# Altium schematic sheet AFE_mezzanine.SchDoc
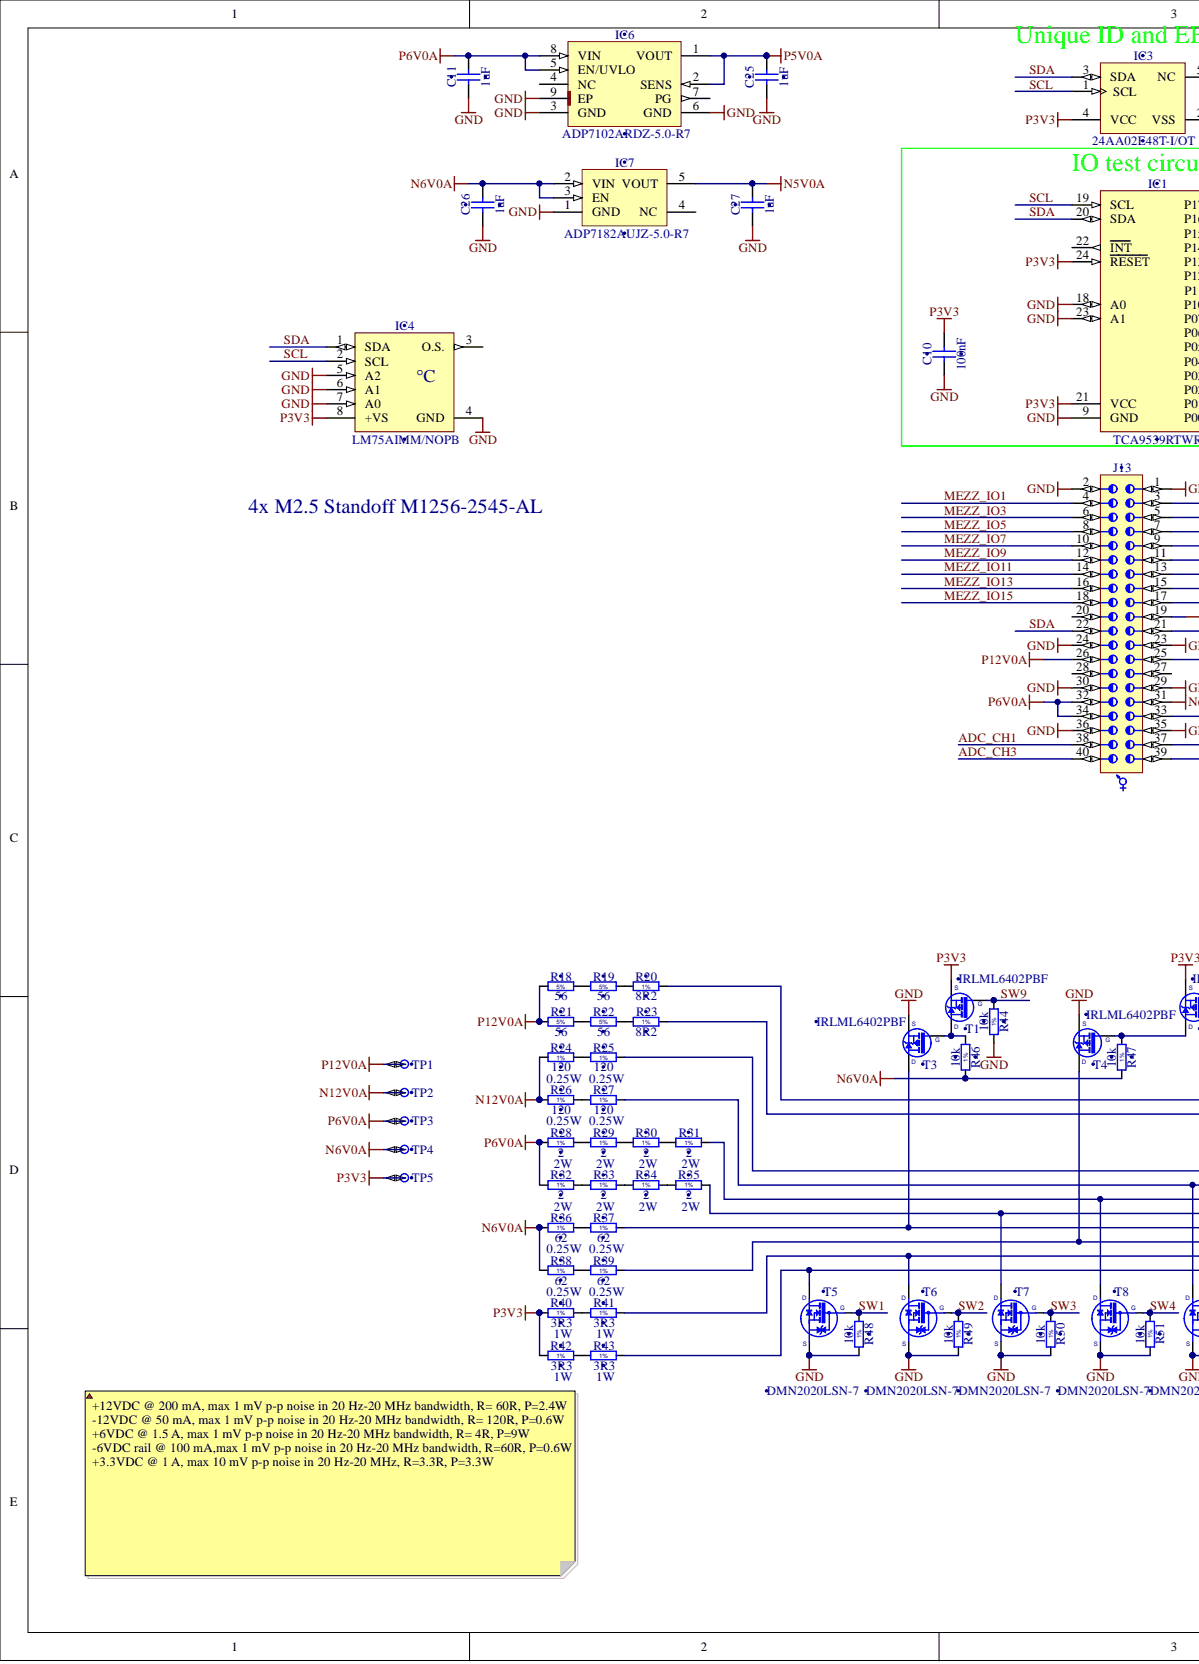
[diagram p0: AFE_mezzanine.SchDoc, left]
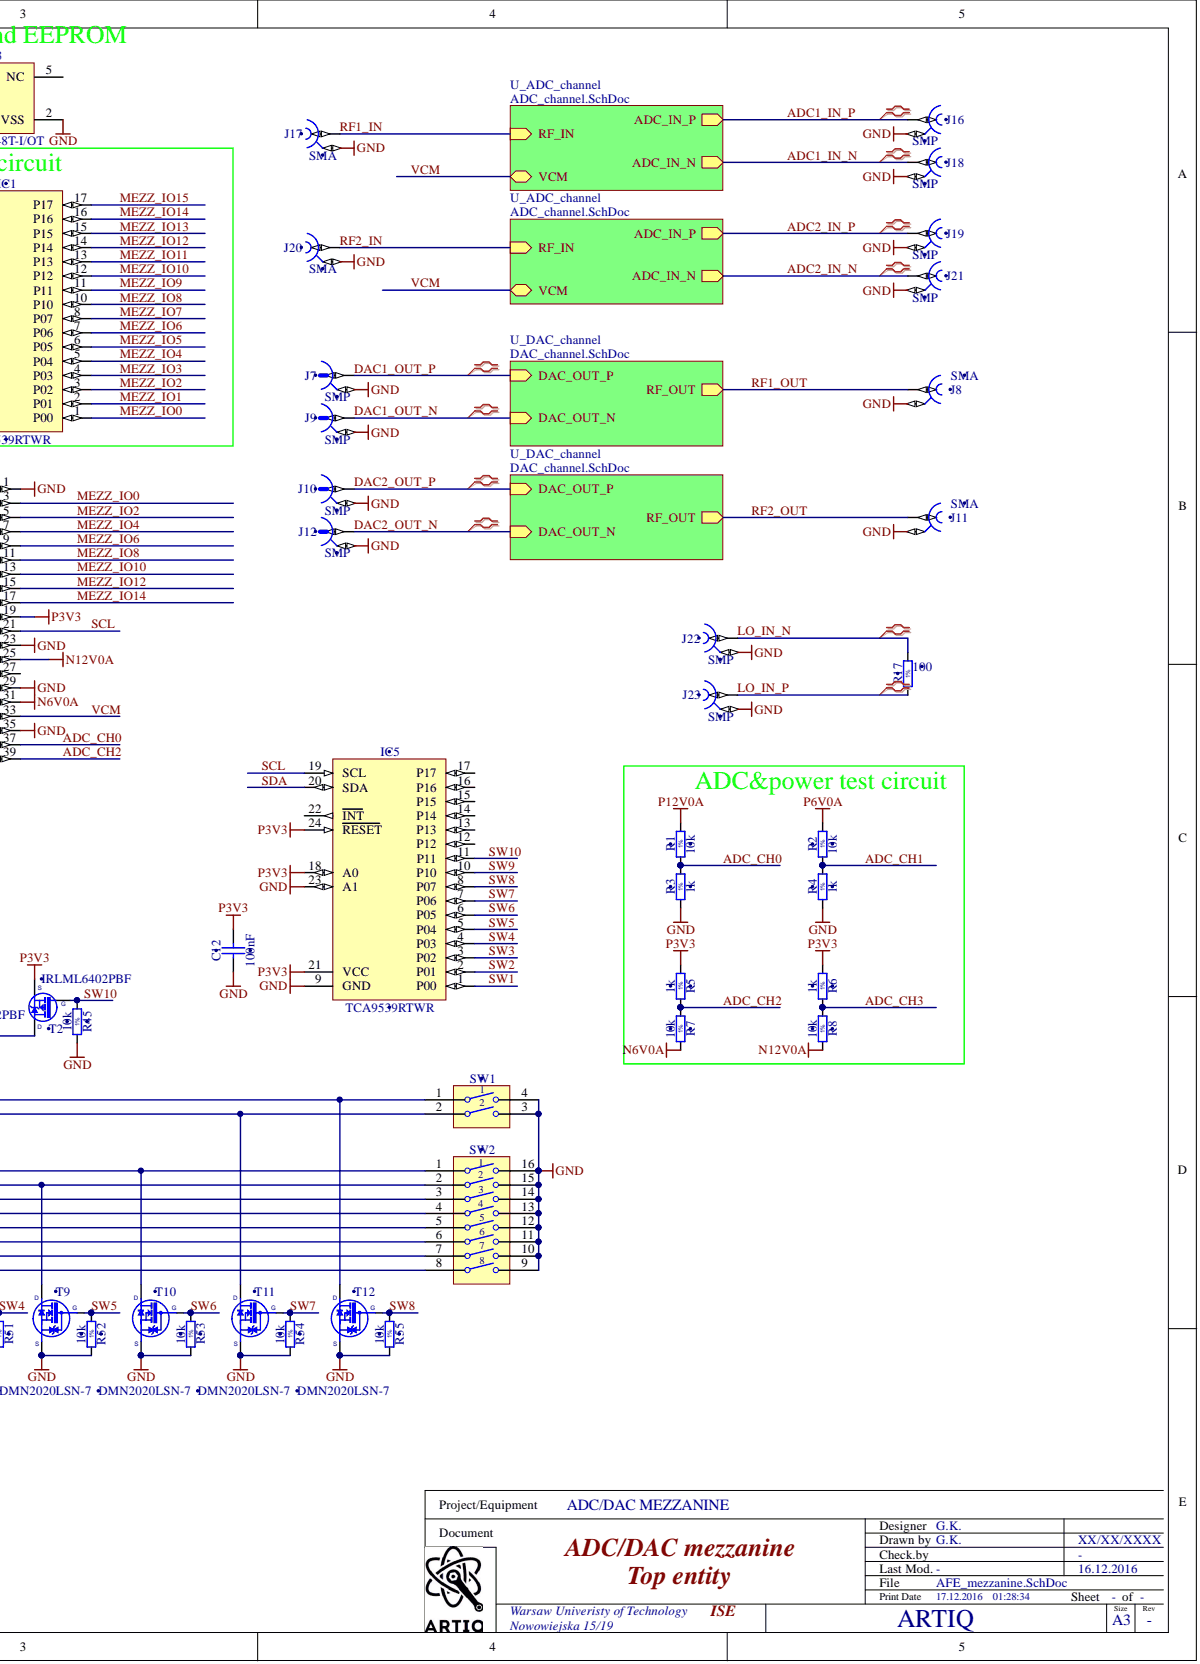
[diagram p1: AFE_mezzanine.SchDoc, right]

## Parts
C10  100nF  [CAPC1005X55N]
C11  1uF  [CAPC2012X140N]
C12  100nF  [CAPC1005X55N]
C25  1uF  [CAPC2012X140N]
C26  1uF  [CAPC2012X140N]
C27  1uF  [CAPC2012X140N]
IC1  TCA9539RTWR  [QFN50P400X400X80-25N-S255]
IC3  24AA02E48T-I/OT  [SOT95P280X145-5N]
IC4  LM75AIMM/NOPB  [SOP65P490X109-8N]
IC5  TCA9539RTWR  [QFN50P400X400X80-25N-S255]
IC6  ADP7102ARDZ-5.0-R7  [SOIC127P600X175-9N-R241X309]
IC7  ADP7182AUJZ-5.0-R7  [SOT95P280X90-5N]
J7  SMP  [MOLEX_85305-0232]
J8  SMA  [TELEGARTNER_J01151A0451]
J9  SMP  [MOLEX_85305-0232]
J10  SMP  [MOLEX_85305-0232]
J11  SMA  [TELEGARTNER_J01151A0451]
J12  SMP  [MOLEX_85305-0232]
J13  Familly  [SAMTEC_LSS-120-03-L-DV-A]  (value not drawn)
J16  SMP  [AMPHENOL_SMP-MSLD-PCT-10]
J17  SMA  [TELEGARTNER_J01151A0921]
J18  SMP  [AMPHENOL_SMP-MSLD-PCT-10]
J19  SMP  [AMPHENOL_SMP-MSLD-PCT-10]
J20  SMA  [TELEGARTNER_J01151A0921]
J21  SMP  [AMPHENOL_SMP-MSLD-PCT-10]
J22  SMP  [AMPHENOL_SMP-MSLD-PCT-10]
J23  SMP  [AMPHENOL_SMP-MSLD-PCT-10]
R1  10k  [RESC1005X40N]
R2  10k  [RESC1005X40N]
R3  1k  [RESC1005X40N]
R4  1k  [RESC1005X40N]
R5  1k  [RESC1005X40N]
R6  1k  [RESC1005X40N]
R7  10k  [RESC1005X40N]
R8  10k  [RESC1005X40N]
R17  100  [RESC1005X40N]
R18  56  [RESC6331X70N]
R19  56  [RESC6331X70N]
R20  8R2  [RESC3216X65N]
R21  56  [RESC6331X70N]
R22  56  [RESC6331X70N]
R23  8R2  [RESC3216X65N]
R24  120  [RESC3216X65N]
R25  120  [RESC3216X65N]
R26  120  Power: 0.25W  [RESC3216X65N]
R27  120  Power: 0.25W  [RESC3216X65N]
R28  2  [RESM11670X449N]
R29  2  [RESM11670X449N]
R30  2  [RESM11670X449N]
R31  2  [RESM11670X449N]
R32  2  Power: 2W  [RESM11670X449N]
R33  2  Power: 2W  [RESM11670X449N]
R34  2  Power: 2W  [RESM11670X449N]
R35  2  Power: 2W  [RESM11670X449N]
R36  62  [RESC3216X65N]
R37  62  [RESC3216X65N]
R38  62  Power: 0.25W  [RESC3216X65N]
R39  62  Power: 0.25W  [RESC3216X65N]
R40  3R3  [RESC6331X70N]
R41  3R3  [RESC6331X70N]
R42  3R3  Power: 1W  [RESC6331X70N]
R43  3R3  Power: 1W  [RESC6331X70N]
R44  10k  [RESC1005X40N]
R45  10k  [RESC1005X40N]
R46  10k  [RESC1005X40N]
R47  10k  [RESC1005X40N]
R48  10k  [RESC1005X40N]
R49  10k  [RESC1005X40N]
R50  10k  [RESC1005X40N]
R51  10k  [RESC1005X40N]
R52  10k  [RESC1005X40N]
R53  10k  [RESC1005X40N]
R54  10k  [RESC1005X40N]
R55  10k  [RESC1005X40N]
SW1  Switch  [SW_OMRON_A6S-2102-H]  (value not drawn)
SW2  Switch  [SW_OMRON_A6S-8102-H]  (value not drawn)
T1  IRLML6402PBF  [SOT95P237X112-3N]
T2  IRLML6402PBF  [SOT95P237X112-3N]
T3  IRLML6402PBF  [SOT95P237X112-3N]
T4  IRLML6402PBF  [SOT95P237X112-3N]
T5  DMN2020LSN-7  [SOT95P285X140-3N]
T6  DMN2020LSN-7  [SOT95P285X140-3N]
T7  DMN2020LSN-7  [SOT95P285X140-3N]
T8  DMN2020LSN-7  [SOT95P285X140-3N]
T9  DMN2020LSN-7  [SOT95P285X140-3N]
T10  DMN2020LSN-7  [SOT95P285X140-3N]
T11  DMN2020LSN-7  [SOT95P285X140-3N]
T12  DMN2020LSN-7  [SOT95P285X140-3N]
TP1  Test Point  [TPS127]  (value not drawn)  (no BOM)
TP2  Test Point  [TPS127]  (value not drawn)  (no BOM)
TP3  Test Point  [TPS127]  (value not drawn)  (no BOM)
TP4  Test Point  [TPS127]  (value not drawn)  (no BOM)
TP5  Test Point  [TPS127]  (value not drawn)  (no BOM)

## Nets
GND: C10.1 C11.1 C12.1 C25.1 C26.1 C27.1 IC1.9(GND) IC1.18(A0) IC1.23(A1) IC3.2(VSS) IC4.4(GND) IC4.5(A2) IC4.6(A1) IC4.7(A0) IC5.9(GND) IC5.23(A1) IC6.3(GND) IC6.6(GND) IC6.9(EP) IC7.1(GND) J7.2 J8.2 J9.2 J10.2 J11.2 J12.2 J13.1 J13.2 J13.23 J13.24 J13.29 J13.30 J13.35 J13.36 J16.2 J17.2 J18.2 J19.2 J20.2 J21.2 J22.2 J23.2 R3.1 R4.1 R44.2 R45.2 R48.2 R49.2 R50.2 R51.2 R52.2 R53.2 R54.2 R55.2 SW1.3 SW1.4 SW2.9 SW2.10 SW2.11 SW2.12 SW2.13 SW2.14 SW2.15 SW2.16 T3.2 T4.2 T5.2 T6.2 T7.2 T8.2 T9.2 T10.2 T11.2 T12.2
N5V0A: C27.2 IC7.5(VOUT)
N6V0A: C26.2 IC7.2(VIN) IC7.3(EN) J13.31 R7.2 R36.1 R38.1 R46.2 R47.2 TP4.1
N12V0A: J13.25 R8.2 R24.1 R26.1 TP2.1
P3V3: C10.2 C12.2 IC1.21(VCC) IC1.24(RESET) IC3.4(VCC) IC4.8(+VS) IC5.18(A0) IC5.21(VCC) IC5.24(RESET) J13.19 R5.1 R6.1 R40.1 R42.1 T1.2 T2.2 TP5.1
P5V0A: C25.2 IC6.1(VOUT) IC6.2(SENS)
P6V0A: C11.2 IC6.5(EN/UVLO) IC6.8(VIN) J13.32 J13.34 R2.2 R28.1 R32.1 TP3.1
P12V0A: J13.26 R1.2 R18.1 R21.1 TP1.1
ADC1_IN_N: J18.1
ADC1_IN_P: J16.1
ADC2_IN_N: J21.1
ADC2_IN_P: J19.1
ADC_CH0: J13.37 R1.1 R3.2
ADC_CH1: J13.38 R2.1 R4.2
ADC_CH2: J13.39 R5.2 R7.1
ADC_CH3: J13.40 R6.2 R8.1
DAC1_OUT_N: J9.1
DAC1_OUT_P: J7.1
DAC2_OUT_N: J12.1
DAC2_OUT_P: J10.1
LO_IN_N: J22.1 R17.2
LO_IN_P: J23.1 R17.1
MEZZ_IO0: IC1.1(P00) J13.3
MEZZ_IO1: IC1.2(P01) J13.4
MEZZ_IO2: IC1.3(P02) J13.5
MEZZ_IO3: IC1.4(P03) J13.6
MEZZ_IO4: IC1.5(P04) J13.7
MEZZ_IO5: IC1.6(P05) J13.8
MEZZ_IO6: IC1.7(P06) J13.9
MEZZ_IO7: IC1.8(P07) J13.10
MEZZ_IO8: IC1.10(P10) J13.11
MEZZ_IO9: IC1.11(P11) J13.12
MEZZ_IO10: IC1.12(P12) J13.13
MEZZ_IO11: IC1.13(P13) J13.14
MEZZ_IO12: IC1.14(P14) J13.15
MEZZ_IO13: IC1.15(P15) J13.16
MEZZ_IO14: IC1.16(P16) J13.17
MEZZ_IO15: IC1.17(P17) J13.18
RF1_IN: J17.1
RF1_OUT: J8.1
RF2_IN: J20.1
RF2_OUT: J11.1
SCL: IC1.19(SCL) IC3.1(SCL) IC4.2(SCL) IC5.19(SCL) J13.21
SDA: IC1.20(SDA) IC3.3(SDA) IC4.1(SDA) IC5.20(SDA) J13.22
SW1: IC5.1(P00) R48.1 T5.1
SW2: IC5.2(P01) R49.1 T6.1
SW3: IC5.3(P02) R50.1 T7.1
SW4: IC5.4(P03) R51.1 T8.1
SW5: IC5.5(P04) R52.1 T9.1
SW6: IC5.6(P05) R53.1 T10.1
SW7: IC5.7(P06) R54.1 T11.1
SW8: IC5.8(P07) R55.1 T12.1
SW9: IC5.10(P10) R44.1 T1.1
SW10: IC5.11(P11) R45.1 T2.1
VCM: J13.33
NetIC1_22: IC1.22(INT)
NetIC3_5: IC3.5(NC)
NetIC4_3: IC4.3(O.S.)
NetIC5_12: IC5.12(P12)
NetIC5_13: IC5.13(P13)
NetIC5_14: IC5.14(P14)
NetIC5_15: IC5.15(P15)
NetIC5_16: IC5.16(P16)
NetIC5_17: IC5.17(P17)
NetIC5_22: IC5.22(INT)
NetIC6_4: IC6.4(NC)
NetIC6_7: IC6.7(PG)
NetIC7_4: IC7.4(NC)
NetJ13_20: J13.20
NetJ13_27: J13.27
NetJ13_28: J13.28
NetR18_2: R18.2 R19.1
NetR19_2: R19.2 R20.1
NetR20_2: R20.2 SW1.1 T12.3
NetR21_2: R21.2 R22.1
NetR22_2: R22.2 R23.1
NetR23_2: R23.2 SW1.2 T11.3
NetR24_2: R24.2 R25.1
NetR25_2: R25.2 SW2.1 T10.3
NetR26_2: R26.2 R27.1
NetR27_2: R27.2 SW2.2 T9.3
NetR28_2: R28.2 R29.1
NetR29_2: R29.2 R30.1
NetR30_2: R30.2 R31.1
NetR31_2: R31.2 SW2.3 T8.3
NetR32_2: R32.2 R33.1
NetR33_2: R33.2 R34.1
NetR34_2: R34.2 R35.1
NetR35_2: R35.2 SW2.4 T7.3
NetR36_2: R36.2 R37.1
NetR37_2: R37.2 SW2.5 T3.3
NetR38_2: R38.2 R39.1
NetR39_2: R39.2 SW2.6 T4.3
NetR40_2: R40.2 R41.1
NetR41_2: R41.2 SW2.7 T6.3
NetR42_2: R42.2 R43.1
NetR43_2: R43.2 SW2.8 T5.3
NetR46_1: R46.1 T1.3 T3.1
NetR47_1: R47.1 T2.3 T4.1

## Library data of the parts
C10 C12 = CC0402_100NF_16V_10%_X5R: Non-polarised capacitor; Manufacturer: GENERIC; Manufacturer1 Part Number: GRM155R61C104KA88D; Part Description: SMD Multilayer Chip Ceramic Capacitor; Database Table Name: Capacitors SMD; TC: X5R; Tolerance: ±10%; Voltage: 16V; Case: 0402; Component Kind: Standard; Component Type: Standard; CreateDate: 10.12.2013; HelpURL: \\cern.ch\dfs\Applications\Altium\Datasheets\CC0402_X5R_MURATA_GRM.pdf; LatestRevisionDate: 10.12.2013; Library Name: Capacitors.DbLib; Manufacturer1 ComponentHeight: 0.55mm; Manufacturer1 Example: MURATA; Manufacturer Part Number: CC0402_100NF_16V_10%_X5R; Mounted: Yes; Part Number: CC0402_100NF_16V_10%_X5R; Pin Count: 2; Sense: No; SMD: Yes; Socket: No; Status: None; Value: 100nF; Author: CERN DEM JLC
C11 C25-C27 = CC0805_1UF_35V_20%_X7R: Non-polarised capacitor; Manufacturer: GENERIC; Manufacturer1 Part Number: GMK212B7105MG-T; Part Description: SMD Multilayer Chip Ceramic Capacitor; Database Table Name: Capacitors SMD; TC: X7R; Tolerance: ±20%; Voltage: 35V; Case: 0805; Component Kind: Standard; Component Type: Standard; CreateDate: 22.06.2011; HelpURL: \\cern.ch\dfs\Applications\Altium\Datasheets\CC0805_X7R_TAIYO-YUDEN_HIGH-VALUE.pdf; LatestRevisionDate: 22.06.2011; Library Name: Capacitors.DbLib; Manufacturer1 ComponentHeight: 1.35mm; Manufacturer1 Example: TAIYO YUDEN; Manufacturer Part Number: CC0805_1UF_35V_20%_X7R; Mounted: Yes; Part Number: CC0805_1UF_35V_20%_X7R; Pin Count: 2; Sense: No; SMD: Yes; Socket: No; Status: Not Recommended; Value: 1uF; Author: CERN DEM JLC
IC1 IC5 = TCA9539RTWR: Low-Voltage 16-Bit I2C and SMBus I/O Expander; Manufacturer: TEXAS INSTRUMENTS; Part Description: Low Voltage 16-Bit I2C and SMBus Low-Power I/O Expander With Interrupt Output, Reset Pin, Configuration Registers; Bonding: No; Database Table Name: Analog & Interface; PressFit: No; Case: QFN24; ComponentHeight: 0.8mm; Component Kind: Standard; Component Type: Standard; CreateDate: 01.12.2015; Device: TCA9539RTWR; HelpURL: \\cern.ch\dfs\Applications\Altium\Datasheets\TCA9539RTWR.pdf; LatestRevisionDate: 01.12.2015; Library Name: ICs And Semiconductors.DbLib; Manufacturer Part Number: TCA9539RTWR; Mounted: Yes; PackageDescription: QFN, 0.50mm Pitch, Square; 24 Pin, 4.00mm X 4.00mm X 0.80mm H Body (w/Thermal Tab 2.55 X 2.55 mm), IPC Medium Density; Part Number: TCA9539RTWR; Pin Count: 25; Sense: No; SMD: Yes; Socket: No; Author: CERN DEM MR
IC3 = 24AA02E48T-I/OT: 1.7V-5.5V, 2K I2C Serial EEPROM With EUI-48 Node Identity; Manufacturer: MICROCHIP TECHNOLOGY; Bonding: No; Database Table Name: Logic; PressFit: No; Case: SOT23-5; ComponentHeight: 1.45mm; Component Kind: Standard; Component Type: Standard; CreateDate: 31.03.2016; Device: 24AA02E48T-I/OT; HelpURL: \\cern.ch\dfs\Applications\Altium\Datasheets\24AA02E48T-I-OT.pdf; LatestRevisionDate: 31.03.2016; Library Name: ICs And Semiconductors.DbLib; Manufacturer Part Number: 24AA02E48T-I/OT; Mounted: Yes; PackageDescription: SOT, 0.95mm pitch; 5 pin, 1.60mm W X 2.90mm L X 1.45mm H body, IPC Medium Density; Part Description: 1.7V-5.5V, 2K I2C Serial EEPROM With EUI-48 Node Identity; Part Number: 24AA02E48T-I/OT; Pin Count: 5; Sense: No; SMD: Yes; Socket: No; Author: CERN DEM MR
IC4 = LM75AIMM/NOPB: Digital Temperature Sensor and Thermal Watchdog with Two-Wire Interface; Manufacturer: TEXAS INSTRUMENTS; Part Description: -25° to +100°C ±2°C Digital Temperature Sensor and Thermal Watchdog with Two-Wire Interface; Bonding: No; Database Table Name: Sensors; PressFit: No; Case: SSOP8; ComponentHeight: 1.09mm; Component Kind: Standard; Component Type: Standard; CreateDate: 15.10.2012; Device: LM75AIMM/NOPB; HelpURL: \\cern.ch\dfs\Applications\Altium\Datasheets\LM75AIMM-NOPB.pdf; LatestRevisionDate: 15.10.2012; Library Name: ICs And Semiconductors.DbLib; Manufacturer Part Number: LM75AIMM/NOPB; Mounted: Yes; PackageDescription: SOP, 0.65mm pitch; 8 pin,3.00mm W X 3.00mm L X 1.09mm H bodyI, PC Medium Density; Part Number: LM75AIMM/NOPB; Pin Count: 8; Sense: No; SMD: Yes; Socket: No; Author: CERN DEM JLC
IC6 = ADP7102ARDZ-5.0-R7: 300 mA, Low Noise, CMOS LDO Linear Regulator; Manufacturer: ANALOG DEVICES; Part Description: 5V, 300mA, Low Noise, CMOS LDO Linear Regulator; Bonding: No; Database Table Name: Regulators; PressFit: No; Case: SOIC8; ComponentHeight: 1.75mm; Component Kind: Standard; Component Type: Standard; CreateDate: 17.03.2014; Device: ADP7102ARDZ-5.0-R7; HelpURL: \\cern.ch\dfs\Applications\Altium\Datasheets\ADP7102ARDZ-5.0-R7.pdf; LatestRevisionDate: 17.03.2014; Library Name: ICs And Semiconductors.DbLib; Manufacturer Part Number: ADP7102ARDZ-5.0-R7; Mounted: Yes; PackageDescription: SOIC 8, Pitch 1.27mm - Body 3.9x4.9mm, (w/thermal tab 2.41mm X 3.098mm), IPC Medium Density; Part Number: ADP7102ARDZ-5.0-R7; Pin Count: 8; Sense: No; SMD: Yes; Socket: No; Author: CERN DEM JLC
IC7 = ADP7182AUJZ-5.0-R7: –5V, 200 mA, Low Noise, Linear Regulator; Manufacturer: ANALOG DEVICES; Part Description: –5V, -200mA, Low Noise, Linear Regulator; Bonding: No; Database Table Name: Regulators; PressFit: No; Case: SOT23-5; ComponentHeight: 0.9mm; Component Kind: Standard; Component Type: Standard; CreateDate: 17.03.2014; Device: ADP7182AUJZ-5.0-R7; HelpURL: \\cern.ch\dfs\Applications\Altium\Datasheets\ADP7182AUJZ-5.0-R7.pdf; LatestRevisionDate: 17.03.2014; Library Name: ICs And Semiconductors.DbLib; Manufacturer Part Number: ADP7182AUJZ-5.0-R7; Mounted: Yes; PackageDescription: SOT23, 0.95mm pitch; 5 pin, 1.75mm W X 3.00mm L, IPC Medium Density; Part Number: ADP7182AUJZ-5.0-R7; Pin Count: 5; Sense: No; SMD: Yes; Socket: No; Author: CERN DEM JLC
J7 J9 J10 J12 = MOLEX_85305-0232: Part Description: 50 Ohms, SMP Surface Mount Plug, Limited Detent; Database Table Name: MOLEX; PressFit: No; ComponentHeight: 4.1mm; Component Kind: Standard; Component Type: Standard; CreateDate: 09.08.2016; Family: SMP; HelpURL: \\cern.ch\dfs\Applications\Altium\Datasheets\MOLEX_85305-0232.pdf; LatestRevisionDate: 09.08.2016; Library Name: Connectors.DbLib; Manufacturer: MOLEX; Manufacturer Part Number: 85305-0232; Mounted: Yes; Part Number: MOLEX_85305-0232; Pin Count: 2; Sense: No; SMD: Yes; Socket: No; Status: None; Author: CERN DEM JMW
J8 J11 = TELEGARTNER_J01151A0451: Part Description: SMA 50 ohms Edge Mount Bulkhead Jack Receptacle; Database Table Name: Miscellaneous; PressFit: No; ComponentHeight: 7mm; Component Kind: Standard; Component Type: Standard; CreateDate: 27.10.2016; Family: SMA; HelpURL: \\cern.ch\dfs\Applications\Altium\Datasheets\TELEGARTNER_J01151A0451.pdf; LatestRevisionDate: 27.10.2016; Library Name: Connectors.DbLib; Manufacturer: TELEGARTNER; Manufacturer Part Number: J01151A0451; Mounted: Yes; Part Number: TELEGARTNER_J01151A0451; Pin Count: 2; Sense: No; SMD: No; Socket: No; Status: None; Author: CERN DEM JLC
J13 = SAMTEC_LSS-120-03-L-DV-A: Connector 40 Hermaphroditic; Part Description: 40 Contacts, Pitch 0.635mm, Razor Beam LSS Vertical High Speed Hermaphroditic Strip (Lead Style 5.49mm); Database Table Name: SAMTEC; PressFit: No; ComponentHeight: 5.57mm; Component Kind: Standard; Component Type: Standard; CreateDate: 10.11.2015; HelpURL: \\cern.ch\dfs\Applications\Altium\Datasheets\SAMTEC_LSS-120-03-L-DV-A.pdf; LatestRevisionDate: 10.11.2015; Library Name: Connectors.DbLib; Manufacturer: SAMTEC; Manufacturer Part Number: LSS-120-03-L-DV-A; Mounted: Yes; Part Number: SAMTEC_LSS-120-03-L-DV-A; Pin Count: 40; Sense: No; SMD: Yes; Socket: No; Status: None; Author: CERN DEM JMW
J16 J18 J19 J21-J23 = AMPHENOL_SMP-MSLD-PCT-10: Part Description: 50 Ohm RF Connector, SMP Straight PCB Jack, Limited Detent, Surface Mount with Thru-Hole Contact; PressFit: No; ComponentHeight: 4.22mm; Component Kind: Standard; Component Type: Standard; CreateDate: 05.12.2016; Database Table Name: AMPHENOL; Family: SMP; HelpURL: \\cern.ch\dfs\Applications\Altium\Datasheets\AMPHENOL_SMP-MSLD-PCT-10.pdf; LatestRevisionDate: 05.12.2016; Library Name: Connectors.DbLib; Manufacturer: AMPHENOL; Manufacturer Part Number: SMP-MSLD-PCT-10; Mounted: Yes; Part Number: AMPHENOL_SMP-MSLD-PCT-10; Pin Count: 2; Sense: No; SMD: Yes; Socket: No; Status: None; Author: CERN DEM JLC
J17 J20 = TELEGARTNER_J01151A0921: Part Description: 50 Ohm SMA Right Angle PCB Jack; Database Table Name: Miscellaneous; PressFit: No; ComponentHeight: 10.65mm; Component Kind: Standard; Component Type: Standard; CreateDate: 06.12.2016; Family: SMA; HelpURL: \\cern.ch\dfs\Applications\Altium\Datasheets\TELEGARTNER_J01151A0921.pdf; LatestRevisionDate: 06.12.2016; Library Name: Connectors.DbLib; Manufacturer: TELEGARTNER; Manufacturer Part Number: J01151A0921; Mounted: Yes; Part Number: TELEGARTNER_J01151A0921; Pin Count: 2; Sense: No; SMD: No; Socket: No; Status: None; Author: CERN DEM JLC
R1 R2 R7 R8 R44-R55 = R0402_10K_1%_0.0625W_100PPM: Resistor - 1%; Manufacturer: GENERIC; Manufacturer1 Part Number: 232270671003L; Part Description: General Purpose Thick Film Chip Resistor; Database Table Name: Resistors SMD; TC: ±100ppm/°C; Case: 0402; Component Kind: Standard; Component Type: Standard; CreateDate: 03.12.2007; HelpURL: \\cern.ch\dfs\Applications\Altium\Datasheets\R0402_Phycomp_RC0402.pdf; LatestRevisionDate: 17.10.2012; Library Name: Resistors.DbLib; Manufacturer1 ComponentHeight: 0.4mm; Manufacturer1 Example: YAGEO PHYCOMP; Manufacturer Part Number: R0402_10K_1%_0.0625W_100PPM; Mounted: Yes; Part Number: R0402_10K_1%_0.0625W_100PPM; Pin Count: 2; Power: 0.0625W; Sense: No; SMD: Yes; Socket: No; Status: Not recommended; Tolerance: ±1%; Value: 10k; Author: CERN DEM JLC
R3-R6 = R0402_1K_1%_0.0625W_100PPM: Resistor - 1%; Manufacturer: GENERIC; Manufacturer1 Part Number: 232270671002L; Part Description: General Purpose Thick Film Chip Resistor; Database Table Name: Resistors SMD; TC: ±100ppm/°C; Case: 0402; Component Kind: Standard; Component Type: Standard; CreateDate: 03.12.2007; HelpURL: \\cern.ch\dfs\Applications\Altium\Datasheets\R0402_Phycomp_RC0402.pdf; LatestRevisionDate: 17.10.2012; Library Name: Resistors.DbLib; Manufacturer1 ComponentHeight: 0.4mm; Manufacturer1 Example: YAGEO PHYCOMP; Manufacturer Part Number: R0402_1K_1%_0.0625W_100PPM; Mounted: Yes; Part Number: R0402_1K_1%_0.0625W_100PPM; Pin Count: 2; Power: 0.0625W; Sense: No; SMD: Yes; Socket: No; Status: Not recommended; Tolerance: ±1%; Value: 1k; Author: CERN DEM JLC
R17 = R0402_100R_1%_0.0625W_100PPM: Resistor - 1%; Manufacturer: GENERIC; Manufacturer1 Part Number: 232270671001L; Part Description: General Purpose Thick Film Chip Resistor; Database Table Name: Resistors SMD; TC: ±100ppm/°C; Case: 0402; Component Kind: Standard; Component Type: Standard; CreateDate: 03.12.2007; HelpURL: \\cern.ch\dfs\Applications\Altium\Datasheets\R0402_Phycomp_RC0402.pdf; LatestRevisionDate: 17.10.2012; Library Name: Resistors.DbLib; Manufacturer1 ComponentHeight: 0.4mm; Manufacturer1 Example: YAGEO PHYCOMP; Manufacturer Part Number: R0402_100R_1%_0.0625W_100PPM; Mounted: Yes; Part Number: R0402_100R_1%_0.0625W_100PPM; Pin Count: 2; Power: 0.0625W; Sense: No; SMD: Yes; Socket: No; Status: Not recommended; Tolerance: ±1%; Value: 100; Author: CERN DEM JLC
R18 R19 R21 R22 = R2512_56R_5%_1W_200PPM: Resistor - 5%; Manufacturer: GENERIC; Manufacturer1 Part Number: 352056RJT; Part Description: Surface Mount Power Resistor; Database Table Name: Resistors SMD; Power: 1W; TC: ±200ppm/°C; Case: 2512; Component Kind: Standard; Component Type: Standard; CreateDate: 21.07.2010; HelpURL: \\cern.ch\dfs\Applications\Altium\Datasheets\R2512_TYCO_3520.pdf; LatestRevisionDate: 21.07.2010; Library Name: Resistors.DbLib; Manufacturer1 ComponentHeight: 0.7mm; Manufacturer1 Example: TYCO ELECTRONICS; Manufacturer Part Number: R2512_56R_5%_1W_200PPM; Mounted: Yes; Part Number: R2512_56R_5%_1W_200PPM; Pin Count: 2; Sense: No; SMD: Yes; Socket: No; Status: None; Tolerance: ±5%; Value: 56; Author: CERN DEM JLC
R20 R23 = R1206_8R2_1%_0.25W_200PPM: Resistor - 1%; Manufacturer: GENERIC; Manufacturer1 Part Number: 232272468208; Part Description: General Purpose Thick Film Chip Resistor; Database Table Name: Resistors SMD; Power: 0.25W; TC: ±200ppm/°C; Case: 1206; Component Kind: Standard; Component Type: Standard; CreateDate: 03.12.2007; HelpURL: \\cern.ch\dfs\Applications\Altium\Datasheets\R1206_Phycomp_RC1206.pdf; LatestRevisionDate: 24.06.2009; Library Name: Resistors.DbLib; Manufacturer1 ComponentHeight: 0.65mm; Manufacturer1 Example: PHYCOMP; Manufacturer Part Number: R1206_8R2_1%_0.25W_200PPM; Mounted: Yes; Part Number: R1206_8R2_1%_0.25W_200PPM; Pin Count: 2; Sense: No; SMD: Yes; Socket: No; Status: Preferred; Tolerance: ±1%; Value: 8R2; Author: CERN DEM JLC
R24 R25 = R1206_120R_1%_0.25W_100PPM: Resistor - 1%; Manufacturer: GENERIC; Manufacturer1 Part Number: NRC12F1200TRF; Part Description: General Purpose Thick Film Chip Resistor; Database Table Name: Resistors SMD; Power: 0.25W; TC: ±100ppm/°C; Case: 1206; Component Kind: Standard; Component Type: Standard; CreateDate: 03.12.2007; HelpURL: \\cern.ch\dfs\Applications\Altium\Datasheets\R1206_NIC_NRC.pdf; LatestRevisionDate: 13.03.2008; Library Name: Resistors.DbLib; Manufacturer1 ComponentHeight: 0.65mm; Manufacturer1 Example: NIC COMPONENT; Manufacturer Part Number: R1206_120R_1%_0.25W_100PPM; Mounted: Yes; Part Number: R1206_120R_1%_0.25W_100PPM; Pin Count: 2; Sense: No; SMD: Yes; Socket: No; Status: Preferred; Tolerance: ±1%; Value: 120; Author: CERN DEM JLC
R26 R27 = R1206_120R_1%_0.25W_100PPM: Resistor - 1%; Manufacturer: GENERIC; Manufacturer1 Part Number: NRC12F1200TRF; Part Description: General Purpose Thick Film Chip Resistor; Database Table Name: Resistors SMD; TC: ±100ppm/°C; Case: 1206; Component Kind: Standard; Component Type: Standard; CreateDate: 03.12.2007; HelpURL: \\cern.ch\dfs\Applications\Altium\Datasheets\R1206_NIC_NRC.pdf; LatestRevisionDate: 13.03.2008; Library Name: Resistors.DbLib; Manufacturer1 ComponentHeight: 0.65mm; Manufacturer1 Example: NIC COMPONENT; Manufacturer Part Number: R1206_120R_1%_0.25W_100PPM; Mounted: Yes; Part Number: R1206_120R_1%_0.25W_100PPM; Pin Count: 2; Sense: No; SMD: Yes; Socket: No; Status: Preferred; Tolerance: ±1%; Value: 120; Author: CERN DEM JLC
R28-R31 = R4527_2R_1%_2W_50PPM: Resistor - 1%; Manufacturer: VISHAY DALE; Manufacturer Part Number: WSC45272R000FEA; Part Description: Surface Mount Precision Power Wirewound Resistor; Database Table Name: Resistors SMD; Power: 2W; TC: ±50ppm/°C; Case: 4527; ComponentHeight: 4.49mm; Component Kind: Standard; Component Type: Standard; CreateDate: 22.03.2011; HelpURL: \\cern.ch\dfs\Applications\Altium\Datasheets\R4527_VISHAY_WSC.pdf; LatestRevisionDate: 22.03.2011; Library Name: Resistors.DbLib; Mounted: Yes; Part Number: R4527_2R_1%_2W_50PPM; Pin Count: 2; Sense: No; SMD: Yes; Socket: No; Status: None; Tolerance: ±1%; Value: 2; Author: CERN DEM JLC
R32-R35 = R4527_2R_1%_2W_50PPM: Resistor - 1%; Manufacturer: VISHAY DALE; Manufacturer Part Number: WSC45272R000FEA; Part Description: Surface Mount Precision Power Wirewound Resistor; Database Table Name: Resistors SMD; TC: ±50ppm/°C; Case: 4527; ComponentHeight: 4.49mm; Component Kind: Standard; Component Type: Standard; CreateDate: 22.03.2011; HelpURL: \\cern.ch\dfs\Applications\Altium\Datasheets\R4527_VISHAY_WSC.pdf; LatestRevisionDate: 22.03.2011; Library Name: Resistors.DbLib; Mounted: Yes; Part Number: R4527_2R_1%_2W_50PPM; Pin Count: 2; Sense: No; SMD: Yes; Socket: No; Status: None; Tolerance: ±1%; Value: 2; Author: CERN DEM JLC
R36 R37 = R1206_62R_1%_0.25W_100PPM: Resistor - 1%; Manufacturer: GENERIC; Manufacturer1 Part Number: NRC12F62R0TRF; Part Description: General Purpose Thick Film Chip Resistor; Database Table Name: Resistors SMD; Power: 0.25W; TC: ±100ppm/°C; Case: 1206; Component Kind: Standard; Component Type: Standard; CreateDate: 03.12.2007; HelpURL: \\cern.ch\dfs\Applications\Altium\Datasheets\R1206_NIC_NRC.pdf; LatestRevisionDate: 13.03.2008; Library Name: Resistors.DbLib; Manufacturer1 ComponentHeight: 0.65mm; Manufacturer1 Example: NIC COMPONENT; Manufacturer Part Number: R1206_62R_1%_0.25W_100PPM; Mounted: Yes; Part Number: R1206_62R_1%_0.25W_100PPM; Pin Count: 2; Sense: No; SMD: Yes; Socket: No; Status: Preferred; Tolerance: ±1%; Value: 62; Author: CERN DEM JLC
R38 R39 = R1206_62R_1%_0.25W_100PPM: Resistor - 1%; Manufacturer: GENERIC; Manufacturer1 Part Number: NRC12F62R0TRF; Part Description: General Purpose Thick Film Chip Resistor; Database Table Name: Resistors SMD; TC: ±100ppm/°C; Case: 1206; Component Kind: Standard; Component Type: Standard; CreateDate: 03.12.2007; HelpURL: \\cern.ch\dfs\Applications\Altium\Datasheets\R1206_NIC_NRC.pdf; LatestRevisionDate: 13.03.2008; Library Name: Resistors.DbLib; Manufacturer1 ComponentHeight: 0.65mm; Manufacturer1 Example: NIC COMPONENT; Manufacturer Part Number: R1206_62R_1%_0.25W_100PPM; Mounted: Yes; Part Number: R1206_62R_1%_0.25W_100PPM; Pin Count: 2; Sense: No; SMD: Yes; Socket: No; Status: Preferred; Tolerance: ±1%; Value: 62; Author: CERN DEM JLC
R40 R41 = R2512_3R3_1%_1W_200PPM: Resistor - 1%; Manufacturer: GENERIC; Manufacturer1 Part Number: 232276363308L or RC2512FK-073R3L; Part Description: Thick Film Chip Resistor; Database Table Name: Resistors SMD; Power: 1W; TC: ±200ppm/°C; Case: 2512; Component Kind: Standard; Component Type: Standard; CreateDate: 21.07.2008; HelpURL: \\cern.ch\dfs\Applications\Altium\Datasheets\R2512_Phycomp_RC2512.pdf; LatestRevisionDate: 17.10.2012; Library Name: Resistors.DbLib; Manufacturer1 ComponentHeight: 0.65mm; Manufacturer1 Example: YAGEO PHYCOMP; Manufacturer Part Number: R2512_3R3_1%_1W_200PPM; Mounted: Yes; Part Number: R2512_3R3_1%_1W_200PPM; Pin Count: 2; Sense: No; SMD: Yes; Socket: No; Status: Preferred; Tolerance: ±1%; Value: 3R3; Author: CERN DEM JLC
R42 R43 = R2512_3R3_1%_1W_200PPM: Resistor - 1%; Manufacturer: GENERIC; Manufacturer1 Part Number: 232276363308L or RC2512FK-073R3L; Part Description: Thick Film Chip Resistor; Database Table Name: Resistors SMD; TC: ±200ppm/°C; Case: 2512; Component Kind: Standard; Component Type: Standard; CreateDate: 21.07.2008; HelpURL: \\cern.ch\dfs\Applications\Altium\Datasheets\R2512_Phycomp_RC2512.pdf; LatestRevisionDate: 17.10.2012; Library Name: Resistors.DbLib; Manufacturer1 ComponentHeight: 0.65mm; Manufacturer1 Example: YAGEO PHYCOMP; Manufacturer Part Number: R2512_3R3_1%_1W_200PPM; Mounted: Yes; Part Number: R2512_3R3_1%_1W_200PPM; Pin Count: 2; Sense: No; SMD: Yes; Socket: No; Status: Preferred; Tolerance: ±1%; Value: 3R3; Author: CERN DEM JLC
SW1 = SW_OMRON_A6S-2102-H: 2 Ways SPST DIP Switch; Manufacturer: OMRON; Part Description: 2 Ways SPST DIP Switch, White Flat Actuator with Seal Tape, Contact Rating 25mA 24VDC; Database Table Name: Switches; PressFit: No; ComponentHeight: 3.8mm; Component Kind: Standard; Component Type: Standard; CreateDate: 25.09.2008; Family: Switch; HelpURL: \\cern.ch\dfs\Applications\Altium\Datasheets\SW_OMRON_A6S-2102-H.pdf; LatestRevisionDate: 25.09.2008; Library Name: Eletro-mechanical.DbLib; Manufacturer Part Number: A6S-2102-H; Mounted: Yes; PackageDescription: Pitch 2.54mm, Body 6.2x6.4mm, 2 Ways SPST Surface-Mounting DIP Switch, OMRON A6S-H; Part Number: SW_OMRON_A6S-2102-H; Pin Count: 4; Sense: No; SMD: Yes; Socket: No; Status: None; Author: CERN DEM JMW
SW2 = SW_OMRON_A6S-8102-H: 8 Ways SPST DIP Switch; Manufacturer: OMRON; Part Description: 8 Ways SPST DIP Switch, White Flat Actuator with Seal Tape, Contact Rating 25mA 24VDC; Database Table Name: Switches; PressFit: No; ComponentHeight: 3.8mm; Component Kind: Standard; Component Type: Standard; CreateDate: 25.09.2008; Family: Switch; HelpURL: \\cern.ch\dfs\Applications\Altium\Datasheets\SW_OMRON_A6S-8102-H.pdf; LatestRevisionDate: 25.09.2008; Library Name: Eletro-mechanical.DbLib; Manufacturer Part Number: A6S-8102-H; Mounted: Yes; PackageDescription: Pitch 2.54mm, Body 21.5x6.4mm, 8 Ways SPST Surface-Mounting DIP Switch, OMRON A6S-H; Part Number: SW_OMRON_A6S-8102-H; Pin Count: 16; Sense: No; SMD: Yes; Socket: No; Status: None; Author: CERN DEM JMW
T1-T4 = IRLML6402PBF: P-CHANNEL MOSFET WITH ZENER DIODE; Manufacturer: INTERNATIONAL RECTIFIER; Part Description: -20V -3.7A P-Channel HEXFET® Power MOSFET; Bonding: No; Database Table Name: Transistors; PressFit: No; Case: SOT23-3; ComponentHeight: 1.12mm; Component Kind: Standard; Component Type: Standard; CreateDate: 29.08.2011; Device: IRLML6402PBF; HelpURL: \\cern.ch\dfs\Applications\Altium\Datasheets\IRLML6402PBF.pdf; LatestRevisionDate: 29.08.2011; Library Name: ICs And Semiconductors.DbLib; Manufacturer Part Number: IRLML6402PBF; Mounted: Yes; PackageDescription: SOT, 0.95mm, pitch; 3 pin,1.30mm W X 2.92mm L X 1.12mm H body, IPC Medium Density; Part Number: IRLML6402PBF; Pin Count: 3; Sense: No; SMD: Yes; Socket: No; Author: CERN DEM JLC
T5-T12 = DMN2020LSN-7: 20V, 6.9A, N-Channel Enhancement Mode MOSFET; Manufacturer: DIODES INCORPORATED; Bonding: No; Database Table Name: Transistors; PressFit: No; Case: SOT23-3; ComponentHeight: 1.15mm; Component Kind: Standard; Component Type: Standard; CreateDate: 17.11.2014; Device: DMN2020LSN-7; HelpURL: \\cern.ch\dfs\Applications\Altium\Datasheets\DMN2020LSN-7.pdf; LatestRevisionDate: 19.11.2014; Library Name: ICs And Semiconductors.DbLib; Manufacturer Part Number: DMN2020LSN-7; Mounted: Yes; PackageDescription: SOT23, 0.95mm Pitch; 3 Pin, 1.6mm W X 3mm L X 1.4mm H Body, IPC Medium Density; Part Description: 20V, 6.9A, N-Channel Enhancement Mode MOSFET; Part Number: DMN2020LSN-7; Pin Count: 3; Sense: No; SMD: Yes; Socket: No; Author: CERN DEM JLC
TP1-TP5 = SMD_PAD_1.27X1.27MM: Part Description: 1.27mm x 1.27mm PCB Copper Area (SMD Test Point); Database Table Name: Pads; Mounted: No; ComponentHeight: 0mm; Component Type: Standard (No BOM); CreateDate: 12.01.2008; Description: Test Point; Family: Test Point; HelpURL: Undefined; LatestRevisionDate: 12.01.2008; Library Name: Eletro-mechanical.DbLib; PackageDescription: 1.27mm x 1.27mm PCB Copper Area (SMD Test Point); Part Number: SMD_PAD_1.27X1.27MM; Pin Count: 1; Sense: No; SMD: Yes; Socket: No; Status: None; Author: CERN DEM JLC
